# Altium schematic sheet list1.SchDoc(list1)
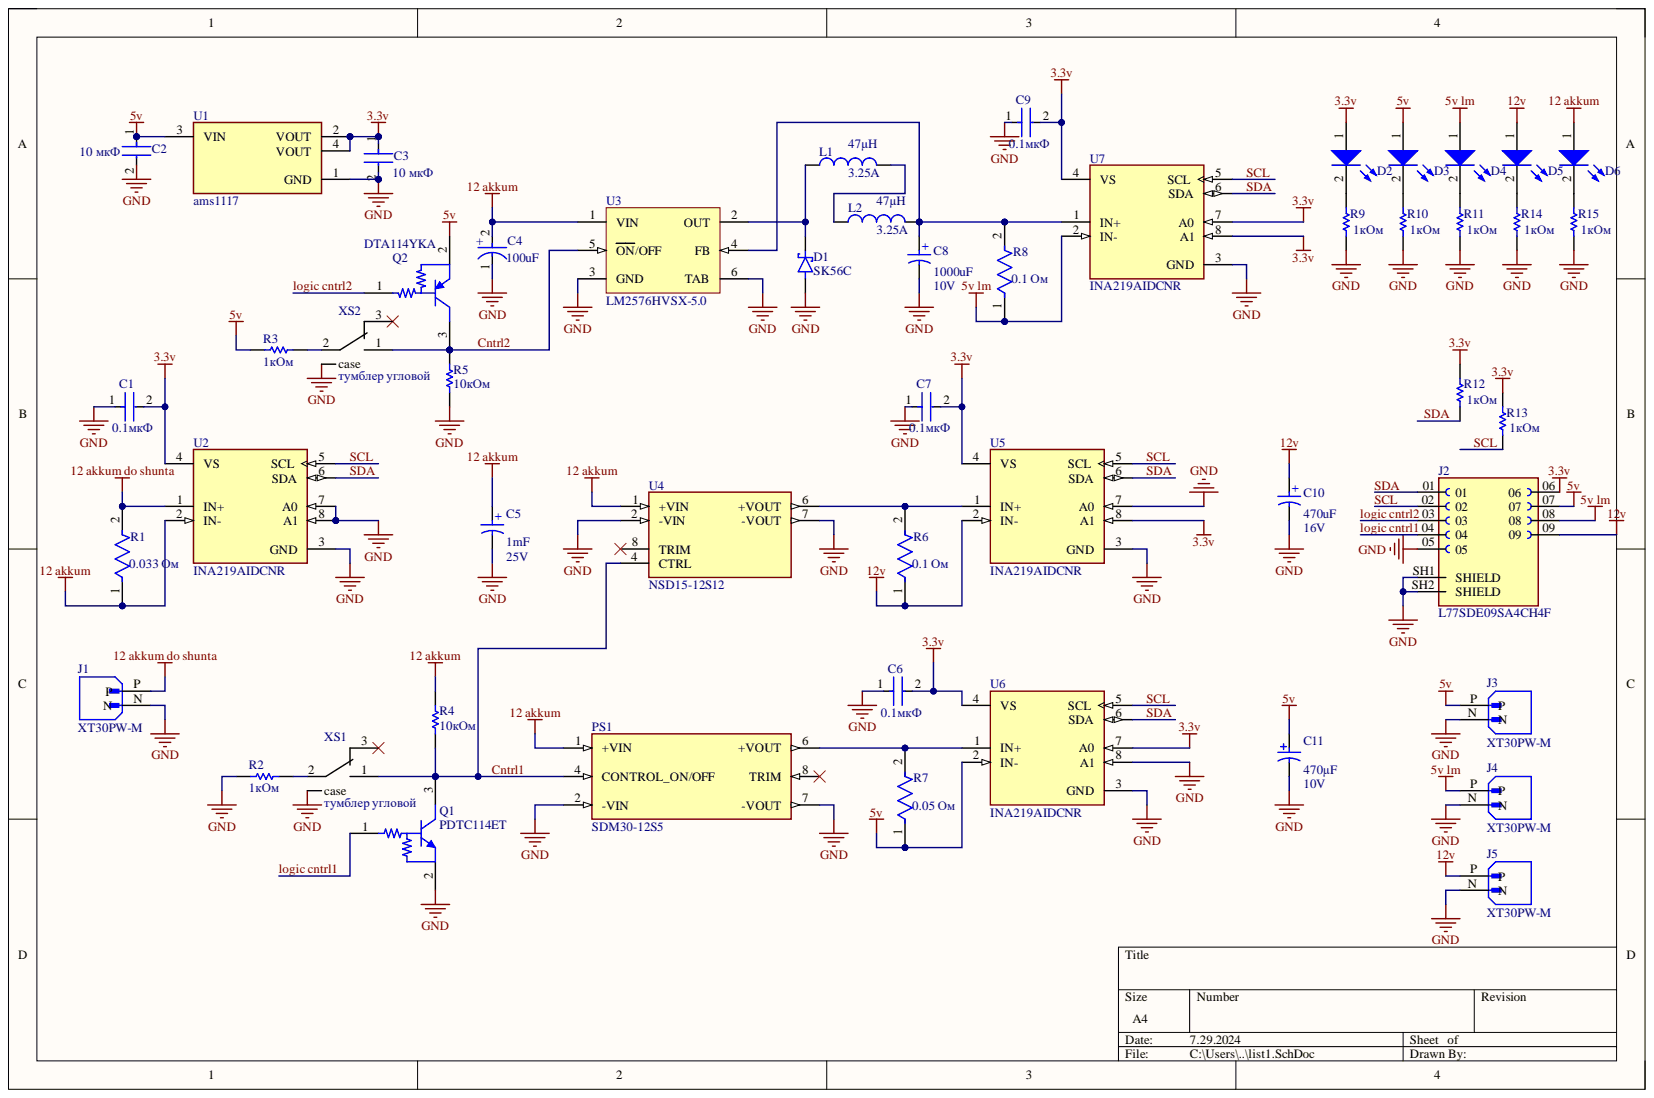
[diagram p0: list1.SchDoc]

## Parts
C1  value 0.1мкФ  [FP-C0805C-DN-IPC_A]  (hidden comment: C0805C104K5RACTU)
C2  value 10 мкФ  [FP-C0805C-DN-IPC_A]  (hidden comment: C0805C104K5RACTU)
C3  value 10 мкФ  [FP-C0805C-DN-IPC_A]  (hidden comment: C0805C104K5RACTU)
C4  Capacitance: 100uF  [FP-T495D107K016ATE125-MFG]  (hidden comment: T495D107K016ATE125)
C5  Capacitance: 1mF  Voltage Rating: 25V  [10*12.5]  (hidden comment: 865060257010)
C6  value 0.1мкФ  [FP-C0805C-DN-IPC_A]  (hidden comment: C0805C104K5RACTU)
C7  value 0.1мкФ  [FP-C0805C-DN-IPC_A]  (hidden comment: C0805C104K5RACTU)
C8  Capacitance: 1000uF  Voltage Rating: 10V  [WCAP-ASLL_D10H10.5]  (hidden comment: 865060257010)
C9  value 0.1мкФ  [FP-C0805C-DN-IPC_A]  (hidden comment: C0805C104K5RACTU)
C10  Capacitance: 470uF  Voltage Rating: 16V  [WCAP-ASLL_D10H10.5]  (hidden comment: 865060257010)
C11  Capacitance: 470µF  Rated Voltage: 10V  [PNSC-FK-V-F_V]  (hidden comment: EEEFK1C471P)
D1  SK56C  [DIOM6859X262N]
D2  Infrared, 1.5V, 30°  [WL-TIRC_3mm]  (value not drawn)
D3  Infrared, 1.5V, 30°  [WL-TIRC_3mm]  (value not drawn)
D4  Infrared, 1.5V, 30°  [WL-TIRC_3mm]  (value not drawn)
D5  Infrared, 1.5V, 30°  [WL-TIRC_3mm]  (value not drawn)
D6  Infrared, 1.5V, 30°  [WL-TIRC_3mm]  (value not drawn)
J1  XT30PW-M  [AMASS_XT30PW-M]
J2  L77SDE09SA4CH4F  [AMPHENOL_L77SDE09SA4CH4F]
J3  XT30PW-M  [AMASS_XT30PW-M]
J4  XT30PW-M  [AMASS_XT30PW-M]
J5  XT30PW-M  [AMASS_XT30PW-M]
L1  Current Rating: 3.25A  Inductance: 47µH  [SUMI-CDRH127_V]  (hidden comment: CDRH127/LDNP-470MC)
L2  Current Rating: 3.25A  Inductance: 47µH  [SUMI-CDRH127_V]  (hidden comment: CDRH127/LDNP-470MC)
PS1  SDM30-12S5  [CONV_SDM30-12S5]
Q1  PDTC114ET  [ONSC-SC-59-3-318D-04_V]
Q2  DTA114YKA  [ONSC-SOT-23-3-318-08_V]
R1  CRCW25121R00FKEG  [FP-CRCW2512-e3-IPC_A]  (value not drawn)
R2  Package Quantity: 1кОм  [RESC2013X70X40ML20T20]  (hidden comment: ERJ-6ENF1001V)
R3  Package Quantity: 1кОм  [RESC2013X70X40ML20T20]  (hidden comment: ERJ-6ENF1001V)
R4  Package Quantity: 10кОм  [RESC2013X70X40ML20T20]  (hidden comment: ERJ-6ENF1001V)
R5  Package Quantity: 10кОм  [RESC2013X70X40ML20T20]  (hidden comment: ERJ-6ENF1001V)
R6  CRCW25121R00FKEG  [FP-CRCW2512-e3-IPC_A]  (value not drawn)
R7  CRCW25121R00FKEG  [FP-CRCW2512-e3-IPC_A]  (value not drawn)
R8  CRCW25121R00FKEG  [FP-CRCW2512-e3-IPC_A]  (value not drawn)
R9  Package Quantity: 1кОм  [RESC2013X70X40ML20T20]  (hidden comment: ERJ-6ENF1001V)
R10  Package Quantity: 1кОм  [RESC2013X70X40ML20T20]  (hidden comment: ERJ-6ENF1001V)
R11  Package Quantity: 1кОм  [RESC2013X70X40ML20T20]  (hidden comment: ERJ-6ENF1001V)
R12  Package Quantity: 1кОм  [RESC2013X70X40ML20T20]  (hidden comment: ERJ-6ENF1001V)
R13  Package Quantity: 1кОм  [RESC2013X70X40ML20T20]  (hidden comment: ERJ-6ENF1001V)
R14  Package Quantity: 1кОм  [RESC2013X70X40ML20T20]  (hidden comment: ERJ-6ENF1001V)
R15  Package Quantity: 1кОм  [RESC2013X70X40ML20T20]  (hidden comment: ERJ-6ENF1001V)
U1  ams1117  [FP-ams1117-IPC_A]
U2  INA219AIDCNR  [FP-DCN0008A-IPC_C]
U3  LM2576HVSX-5.0  [KTT0005B_M]
U4  NSD15-12S12  [CONV_NSD15-12S5]
U5  INA219AIDCNR  [FP-DCN0008A-IPC_C]
U6  INA219AIDCNR  [FP-DCN0008A-IPC_C]
U7  INA219AIDCNR  [FP-DCN0008A-IPC_C]
XS1  тумблер угловой  [тумблер угловой]
XS2  тумблер угловой  [тумблер угловой]

## Nets
3.3v: C1.2 C3.1 C6.2 C7.2 C9.2 D2.1 J2.06 R12.2 R13.2 U1.2(VOUT) U1.4(VOUT) U2.4(VS) U5.4(VS) U5.8(A1) U6.4(VS) U6.7(A0) U7.4(VS) U7.7(A0) U7.8(A1)
5v: C2.1 C11.1 D3.1 J2.07 J3.P Q2.2 R3.2 R7.1 U1.3(VIN) U6.2(IN-)
5v lm: D4.1 J2.08 J4.P R8.1 U7.2(IN-)
12 akkum: C4.2 C5.1 D6.1 PS1.1(+VIN) R1.1 R4.1 U2.2(IN-) U3.1(VIN) U4.1(+VIN)
12 akkum do shunta: J1.P R1.2 U2.1(IN+)
12v: C10.1 D5.1 J2.09 J5.P R6.1 U5.2(IN-)
GND: C1.1 C2.2 C3.2 C4.1 C5.2 C6.1 C7.1 C8.2 C9.1 C10.2 C11.2 D1.A J1.N J2.05 J2.SH1(SHIELD) J2.SH2(SHIELD) J3.N J4.N J5.N PS1.2(-VIN) PS1.7(-VOUT) Q1.2 R2.2 R5.1 R9.1 R10.1 R11.1 R14.1 R15.1 U1.1(GND) U2.3(GND) U2.7(A0) U2.8(A1) U3.3(GND) U3.6(TAB) U4.2(-VIN) U4.7(-VOUT) U5.3(GND) U5.7(A0) U6.3(GND) U6.8(A1) U7.3(GND) XS1.0(case) XS2.0(case)
Cntrl1: PS1.4(CONTROL_ON/OFF) Q1.3 R4.2 U4.4(CTRL) XS1.1
Cntrl2: Q2.3 R5.2 U3.5(ON/OFF) XS2.1
logic cntrl1: J2.04 Q1.1
logic cntrl2: J2.03 Q2.1
SCL: J2.02 R13.1 U2.5(SCL) U5.5(SCL) U6.5(SCL) U7.5(SCL)
SDA: J2.01 R12.1 U2.6(SDA) U5.6(SDA) U6.6(SDA) U7.6(SDA)
NetC8_1: C8.1 L2.2 R8.2 U3.4(FB) U7.1(IN+)
NetD1_C: D1.C L1.1 U3.2(OUT)
NetD2_2: D2.2 R9.2
NetD3_2: D3.2 R10.2
NetD4_2: D4.2 R11.2
NetD5_2: D5.2 R14.2
NetD6_2: D6.2 R15.2
NetL1_2: L1.2 L2.1
NetPS1_6: PS1.6(+VOUT) R7.2 U6.1(IN+)
NetR2_1: R2.1 XS1.2
NetR3_1: R3.1 XS2.2
NetR6_2: R6.2 U4.6(+VOUT) U5.1(IN+)

Not connected: PS1 8(TRIM); U4 8(TRIM); XS1 3; XS2 3

## Library data of the parts
C1 C6 C7 C9 = CMP-2007-00279-2: CAP CER 0.1UF 50V X7R 0805; Manufacturer: KEMET; Capacitance: 0.10 μF; Material: Ceramic; Temperature Coefficient: 30ppm/°C; Tolerance: 0.1; Automotive: No; Category: Cap; Component Kind: Standard; ComponentLink2Description: Datasheet; ComponentLink2URL: https://content.kemet.com/datasheets/KEM_C1003_C0G_SMD.pdf; Device Class L1: Passive Components; Device Class L2: Capacitors; Device Class L3: Ceramic Capacitors; Digikey Description: CAP CER 0.1UF 50V X7R 0805; Height: 0.88mm; Ipc Land Pattern Name: CAPC200125X78; Lead Free: Yes; Library Name: Components - cap0805.IntLib; Manufacturer Part Number: C0805C104K5RACTU; Mouser Description: Cap Ceramic 0.1uF 50V X7R 10% SMD 2.0 x 1.2 mm 125 C Paper T/R; Octopart: https://octopart.com/c0805c104k5ractu-kemet-143926; Package: C0805C-DN; RoHS: TRUE; Temperature: -55°C to +125°C; Temperature Characteristic: X7R; Temperature Range High: +125°C; Temperature Range Low: -55°C; Voltage Rating: 50 V
C2 C3 = CMP-2007-00279-2: CAP CER 10UF 50V X7R 0805; Manufacturer: KEMET; Capacitance: 0.10 μF; Material: Ceramic; Temperature Coefficient: 30ppm/°C; Tolerance: 0.1; Automotive: No; Category: Cap; Component Kind: Standard; ComponentLink2Description: Datasheet; ComponentLink2URL: https://content.kemet.com/datasheets/KEM_C1003_C0G_SMD.pdf; Device Class L1: Passive Components; Device Class L2: Capacitors; Device Class L3: Ceramic Capacitors; Digikey Description: CAP CER 0.1UF 50V X7R 0805; Height: 0.88mm; Ipc Land Pattern Name: CAPC200125X78; Lead Free: Yes; Library Name: Components - cap0805.IntLib; Manufacturer Part Number: C0805C104K5RACTU; Mouser Description: Cap Ceramic 0.1uF 50V X7R 10% SMD 2.0 x 1.2 mm 125 C Paper T/R; Octopart: https://octopart.com/c0805c104k5ractu-kemet-143926; Package: C0805C-DN; RoHS: TRUE; Temperature: -55°C to +125°C; Temperature Characteristic: X7R; Temperature Range High: +125°C; Temperature Range Low: -55°C; Voltage Rating: 50 V
C4 = CMP-2000-07207-2: CAP TANT 100UF 10% 16V 2917; Dielectric Material: Tantalum; Dissipation Factor: 8%; ESR (Equivalent Series Resistance): 125mR; Life (Hours): 2000Hour; Polarity: Polar; Case Code (Imperial): 2917; Case Code (Metric): 7343; Case/Package: 2917; Component Kind: Standard; Construction: Flat; Depth: 4.3mm; Failure Rate: 0.001%; Features: General Purpose; Height: 2.8mm; Height - Seated (Max): 0.122inch; Length: 7.3mm; Library Name: Altium Content Vault; Max Operating Temperature: 125°C; Min Operating Temperature: -55°C; Mount: Surface Mount; Number of Pins: 2; Package Quantity: 1; Packaging: Tape and Reel; Radiation Hardening: No; REACH SVHC: No SVHC; RoHS Compliant: Yes; Series: T495; Termination: SMD/SMT; Tolerance: 10%; Voltage: 16V; Voltage Rating: 16V; Voltage Rating (DC): 16V; Weight: 0.023634oz; Width: 0.169inch
C5 = konder: 865060257010 WCAP-ASLL Aluminum Electrolytic Capacitors 1000uf 25V; NexarPartId: 54202466; ESR (Equivalent Series Resistance): 99.9268mΩ; Impedance: 90mΩ; Insulation Resistance: 100kΩ; Leakage Current: 100µA; Life (Hours): 5000hours; Polarity: Polar; Ripple Current: 690mA; Tolerance: 20%; Voltage Rating (DC): 10V; Case/Package: Radial; Component Kind: Standard; Diameter: 10mm; Height: 10.5mm; Height - Seated (Max): 10.4902mm; Length: 12.5mm; Library Name: Components - 06.07.24 - 21.SchLib; Max Operating Temperature: 105°C; Min Operating Temperature: -55°C; Mount: Surface Mount; REACH SVHC: Unknown; Ripple Current (AC): 690mA; RoHS: Compliant
C8 = CMP-03913-54202466-2: 865060257010 WCAP-ASLL Aluminum Electrolytic Capacitors 1000uf 10v; ESR (Equivalent Series Resistance): 99.9268mΩ; Impedance: 90mΩ; Insulation Resistance: 100kΩ; Leakage Current: 100µA; Life (Hours): 5000hours; Polarity: Polar; Ripple Current: 690mA; Tolerance: 20%; Case/Package: Radial; Component Kind: Standard; Diameter: 10mm; Height: 10.5mm; Height - Seated (Max): 10.4902mm; Length: 10.5mm; Library Name: Components - 06.07.24 - 21.SchLib; Max Operating Temperature: 105°C; Min Operating Temperature: -55°C; Mount: Surface Mount; NexarPartId: 54202466; REACH SVHC: Unknown; Ripple Current (AC): 690mA; RoHS: Compliant; Voltage Rating (DC): 10V
C10 = CMP-03913-54202466-2: 865060257010 WCAP-ASLL Aluminum Electrolytic Capacitors 470uf 16v; ESR (Equivalent Series Resistance): 99.9268mΩ; Impedance: 90mΩ; Insulation Resistance: 100kΩ; Leakage Current: 100µA; Life (Hours): 5000hours; Polarity: Polar; Ripple Current: 690mA; Tolerance: 20%; Voltage Rating (DC): 10V; Case/Package: Radial; Component Kind: Standard; Diameter: 10mm; Height: 10.5mm; Height - Seated (Max): 10.4902mm; Length: 10.5mm; Library Name: Components - 06.07.24 - 21.SchLib; Max Operating Temperature: 105°C; Min Operating Temperature: -55°C; Mount: Surface Mount; NexarPartId: 54202466; REACH SVHC: Unknown; Ripple Current (AC): 690mA; RoHS: Compliant
C11 = CMP-2000-06204-1: Aluminum Electrolytic Capacitor, 470 uF, +/- 20%, 10 V, 2-Pin SMD (Size Code F), -55 to 105 degC, RoHS, Tape and Reel; Manufacturer: Panasonic; Impedance: 0.16O; Lifetime@Temperature: 2000Hrs@105°C; Ripple Current: 600mA; Type: Can; Case Size/Dimension: Ø8x10.2mm; Component Kind: Standard; Datasheet URL: http://industrial.panasonic.com/cdbs/www-data/pdf/RDE0000/ABA0000C1181.pdf; Datasheet Version: 03/2016; Library Name: Altium Content Vault; Manufacturer URL: http://www.panasonic.com/; Mounting Technology: SurfaceMount; Operating Temp Range: -55to+105°C; Operating Temp-Max: 105°C; Package Description: 2-Pin Electrolytic Aluminum Capacitor, Body 8.3 x 8.3 mm; Package Reference: FK-V-F; Package URL: http://industrial.panasonic.com/cdbs/www-data/pdf/RDE0000/DME0000COL48.pdf; Series: FK
D1 = SK56C: 5A, 60V, Schottky Rectifier; MF: Taiwan Semiconductor; Availability: In Stock; Check_prices: https://www.snapeda.com/parts/SK56C/Taiwan+Semiconductor/view-part/?ref=eda; Component Kind: Standard; Library Name: SK56C.SchLib; MP: SK56C; Package: SMC-2 Taiwan Semiconductor; Price: None; Purchase-URL: https://pricing.snapeda.com/search/part/SK56C/?ref=eda; SnapEDA_Link: https://www.snapeda.com/parts/SK56C/Taiwan+Semiconductor/view-part/?ref=snap
D2-D6 = 15400385F3590: WL-TIRC THT Infrared Round Waterclear, 3mm, 60mW/sr, Infrared, 1.5V, 30°; Manufacturer: Wurth Elektronik; Centroid Wavelength: 845nm; Chip Technology: AlGaAs; Category: Infrared LEDs; Component Kind: Standard; ComponentLink1Description: Website Link; ComponentLink1URL: https://www.we-online.com/redexpert/article/15400385F3590?ad; ComponentLink2Description: Datasheet Link; ComponentLink2URL: https://www.we-online.com/redexpert/spec/15400385F3590?ad; Diameter: 3.85mm; Emitting Color: Infrared; Forward Voltage: 1.5V; Height: 5.3mm; Library Name: WL-TIRC.SchLib; Manufacturer Part Number: 15400385F3590; Match Code: WL-TIRC; Mount: Through Hole; Operating Temperature Max: 85°C; Operating Temperature Min: -40°C; Packaging: Bulk; Radiant Intensity: 60mW/sr; Simulation: THT_3mm_15400385F3590; Viewing Angle: 30°
J1 J3-J5 = XT30PW-M: Socket; DC supply; XT30; male; PIN: 2; on PCBs; THT; Colour: yellow; MF: AMASS; Check_prices: https://www.snapeda.com/parts/XT30PW-M/AMASS/view-part/?ref=eda; Component Kind: Standard; Library Name: XT30PW-M.IntLib; MP: XT30PW-M; Package: None; SnapEDA_Link: https://www.snapeda.com/parts/XT30PW-M/AMASS/view-part/?ref=snap
J2 = L77SDE09SA4CH4F: Connector d-sub rt angle pcb recept 4-40 threaded hole w/screwlock 9 socket cont | Amphenol Commercial (Amphenol CS) L77SDE09SA4CH4F; MF: Amphenol ICC (Commercial Products); Check_prices: https://www.snapeda.com/parts/L77SDE09SA4CH4F/Amphenol/view-part/?ref=eda; Component Kind: Standard; Library Name: L77SDE09SA4CH4F.IntLib; MP: L77SDE09SA4CH4F; Package: None; SnapEDA_Link: https://www.snapeda.com/parts/L77SDE09SA4CH4F/Amphenol/view-part/?ref=snap
L1 L2 = CMP-2000-06084-1: Power Inductor, 10 uH, +/- 20%, -40 to 100 degC, 2-Pin SMD, RoHS, Tape and Reel; Manufacturer: Sumida; Catalog Drawings: CDRH127SeriesFrontCDRH127SeriesSideCDRH127SeriesBottom; DC Resistance (DCR): 21.6mOhmMax; Frequency - Test: 1kHz; Online Catalog: CDRHSeries; Other Names: 308-1457-1; Product Training Modules: PowerInductorBasics; Shielding: Shielded; Category: Inductors,Coils,Chokes; Component Kind: Standard; Current - Saturation: -; Datasheet URL: http://products.sumida.com/products/pdf/CDRH127.pdf; Datasheet Version: 01/2014; Family: FixedInductors; Frequency - Self Resonant: -; Height - Seated (Max): 0.315"(8.00mm); Library Name: Altium Content Vault; Manufacturer URL: http://www.sumida.com/; Material - Core: -; Mounting Technology: SurfaceMount; Mounting Type: SurfaceMount; Operating Temperature: -40°C~100°C; Package / Case: Nonstandard; Package Description: 2-Pin Surface Mount Device, Body 12 x 12 mm; Package Reference: CDRH127; Packaging: CutTape(CT); Q @ Freq: -; Ratings: -; Series: CDRH127; Size / Dimension: 0.472"Lx0.472"W(12.00mmx12.00mm); Standard Package: 1; Tolerance: ±20%; Type: -
PS1 = SDM30-12S5: Isolated Module DC DC Converter 1 Output 5V 5A 9.2V - 18V Input; MF: MEAN WELL; Check_prices: https://www.snapeda.com/parts/SDM30-12S5/MEAN%20WELL%20USA%20Inc./view-part/9848/?ref=eda; Component Kind: Standard; Digi-Key_Part_Number: 1866-4448-ND; Library Name: SDM30-12S5.SchLib; MP: SDM30-12S5; Package: DIP-8 Mean Well; Purchase-URL: https://pricing.snapeda.com/search/part/SDM30-12S5/?ref=eda; SnapEDA_Link: https://www.snapeda.com/parts/SDM30-12S5/MEAN%20WELL%20USA%20Inc./view-part/9848/?ref=snap
Q1 = CMP-0630-00125-1: Digital Transistor (BRT), R1=47 kOhm, R2=47 kOhm, NPN Transistor, 3-Pin SC-59, Pb-Free, Tape and Reel пох какой цифровой транзюк; Manufacturer: On Semiconductor; PartNumber: MUN2213T1G; hFE Min: 80; IC Continuous (A): 0.1; R1/R2 Typ: 1; V(BR)CEO Min (V): 50; Component Kind: Standard; ComponentLink1Description: Manufacturer URL; ComponentLink1URL: http://www.onsemi.com/; ComponentLink2Description: Datasheet; ComponentLink2URL: http://www.onsemi.cn/pub_link/Collateral/DTC144E-D.PDF; ComponentLink3Description: Package Specification; ComponentLink3URL: http://www.onsemi.cn/pub_link/Collateral/318D-04.PDF; DatasheetVersion: Rev. 2; Library Name: Components - NPN Digital.IntLib; Mounting Technology: Surface Mount; PackageDescription: 3-Pin Small Outline Transistor, Body 2.9 x 1.5 mm, Pitch 0.95 mm; PackageReference: SC-59-3-318D-04; PackageVersion: Rev. H, 06/2012; Packing: Tape and Reel; Polarity: NPN; RoHS: TRUE
Q2 = CMP-0630-00280-1: Digital Transistor (BRT), R1=4.7 kOhm, R2=infinity kOhm, PNP Transistor, 3-Pin SOT-23, Pb-Free, Tape and Reel; Manufacturer: On Semiconductor; PartNumber: SMMUN2116LT3G; hFE Min: 160; IC Continuous (A): 0.1; V(BR)CEO Min (V): 50; Component Kind: Standard; ComponentLink1Description: Manufacturer URL; ComponentLink1URL: http://www.onsemi.com/; ComponentLink2Description: Datasheet; ComponentLink2URL: http://www.onsemi.cn/pub_link/Collateral/DTA143T-D.PDF; ComponentLink3Description: Package Specification; ComponentLink3URL: http://www.onsemi.cn/pub_link/Collateral/318-08.PDF; DatasheetVersion: Rev. 2; Library Name: Components - PNP2 Digital.IntLib; Mounting Technology: Surface Mount; PackageDescription: 3-Pin Small Outline Transistor, Body 2.92 x 1.3 mm, Pitch 0.95 mm; PackageReference: SOT-23-3-318-08; PackageVersion: Rev. AP, 11/2009; Packing: Tape and Reel; Polarity: PNP; RoHS: TRUE
R1 = CMP-02407-006956-1: RES Thick Film, 1Ω, 1%, 1W, 100ppm/°C, 2512; Resistance: 0.033 Ом; Voltage Rating: 500V; Case Code (Imperial): 2512; Case Code (Metric): 6432; Case/Package: 2512; Component Kind: Standard; Composition: Thick Film; Contact Plating: Tin; Depth: 3.15mm; Features: Automotive AEC-Q200; Height: 0.6mm; Lead Free: Lead Free; Length: 6.3mm; Library Name: Components - 2512 res.IntLib; Max Operating Temperature: 155°C; Max Power Dissipation: 1W; Military Standard: Not; Min Operating Temperature: -55°C; Mount: Surface Mount; Number of Pins: 2; Number of Terminations: 2; Package Quantity: 2000; Packaging: Tape and Reel; Power Rating: 1W; Radiation Hardening: No; REACH SVHC: No SVHC; Temperature Coefficient: 100ppm/°C; Termination: SMD/SMT; Tolerance: 1%; Voltage Rating (DC): 500V; Weight: 0.001429oz; Width: 0.124inch
R2-R5 R9-R15 = CMP-2001-04375-5: Chip Resistor, 1 KOhm, +/- 1%, 0.125 W, -55 to 155 degC, 0805 (2012 Metric), RoHS, Tape and Reel; Manufacturer: Panasonic; Voltage Rating: 150 V; Component Kind: Standard; ComponentLink1Description: Manufacturer URL; ComponentLink1URL: http://www.panasonic.com/; ComponentLink2Description: Datasheet; ComponentLink2URL: http://industrial.panasonic.com/cdbs/www-data/pdf/RDA0000/AOA0000C86.pdf; DatasheetVersion: 04/2015; Height: 0.6 mm; Length: 2 mm; Library Name: Components res 0805.IntLib; Max Operating Temperature: 155 degC; Min Operating Temperature: -55 degC; Mount: Surface Mount; Mounting Technology: Surface Mount; PackageDescription: 2-Pin Surface Mount Device, Body 2 x 1.25 mm; PackageReference: 0805; Packaging: Cut Tape; REACH SVHC: Yes; RoHSCompliant: true
R6 R8 = CMP-02407-006956-1: RES Thick Film, 1Ω, 1%, 1W, 100ppm/°C, 2512; Resistance: 0.1 Ом; Voltage Rating: 500V; Case Code (Imperial): 2512; Case Code (Metric): 6432; Case/Package: 2512; Component Kind: Standard; Composition: Thick Film; Contact Plating: Tin; Depth: 3.15mm; Features: Automotive AEC-Q200; Height: 0.6mm; Lead Free: Lead Free; Length: 6.3mm; Library Name: Components - 2512 res.IntLib; Max Operating Temperature: 155°C; Max Power Dissipation: 1W; Military Standard: Not; Min Operating Temperature: -55°C; Mount: Surface Mount; Number of Pins: 2; Number of Terminations: 2; Package Quantity: 2000; Packaging: Tape and Reel; Power Rating: 1W; Radiation Hardening: No; REACH SVHC: No SVHC; Temperature Coefficient: 100ppm/°C; Termination: SMD/SMT; Tolerance: 1%; Voltage Rating (DC): 500V; Weight: 0.001429oz; Width: 0.124inch
R7 = CMP-02407-006956-1: RES Thick Film, 1Ω, 1%, 1W, 100ppm/°C, 2512; Resistance: 0.05 Ом; Voltage Rating: 500V; Case Code (Imperial): 2512; Case Code (Metric): 6432; Case/Package: 2512; Component Kind: Standard; Composition: Thick Film; Contact Plating: Tin; Depth: 3.15mm; Features: Automotive AEC-Q200; Height: 0.6mm; Lead Free: Lead Free; Length: 6.3mm; Library Name: Components - 2512 res.IntLib; Max Operating Temperature: 155°C; Max Power Dissipation: 1W; Military Standard: Not; Min Operating Temperature: -55°C; Mount: Surface Mount; Number of Pins: 2; Number of Terminations: 2; Package Quantity: 2000; Packaging: Tape and Reel; Power Rating: 1W; Radiation Hardening: No; REACH SVHC: No SVHC; Temperature Coefficient: 100ppm/°C; Termination: SMD/SMT; Tolerance: 1%; Voltage Rating (DC): 500V; Weight: 0.001429oz; Width: 0.124inch
U1 = CMP-209535-000002-1: 1A LOW DROPOUT VOLTAGE REGULATOR 3.3V; Component Kind: Standard; Library Name: Components - ldo 3.3 ams1117.IntLib; RoHS Compliant: Yes
U2 U5-U7 = CMP-0704-00041-3: IC CURRENT MONITOR 1% SOT23-8; Bandwidth: 3.4MHz; Common Mode Rejection Ratio: 100dB; Input Offset Voltage (Vos): 100uV; Max Input Voltage: 26V; Max Supply Current: 5mA; Max Supply Voltage: 5.5V; Min Input Voltage: 0V; Min Supply Voltage: 3V; Nominal Supply Current: 700uA; Number of Amplifiers: 1; Operating Supply Current: 1mA; Output Current: 10mA; Output Type: Digital; Accuracy: 1%; Case/Package: SOT-23-8; Component Kind: Standard; Contact Plating: Gold; Features: I²C; Height: 1.3mm; Lead Free: Lead Free; Length: 3mm; Library Name: Components - ina219.SchLib; Max Operating Temperature: 125°C; Min Operating Temperature: -40°C; Number of Pins: 8; Output Current per Channel: 10mA; Package Quantity: 3000; Packaging: Tape and Reel; Quiescent Current: 700uA; Radiation Hardening: No; REACH SVHC: No SVHC; RoHS Compliant: Yes; Series: Zero-Drift; Supply Current: 700uA; Weight: 0.001411oz; Width: 1.75mm
U3 = CMP-0069-00141-3: SIMPLE SWITCHER® 3A Step-Down Voltage Regulator, 5-pin TO-263; Manufacturer: Texas Instruments; PackageTopMarking: NSUZXYTTE#*LM2576*HVS-5.0 P+; PowerWise: N; Code_JEDEC: MO-169-AB; Component Kind: Standard; ComponentLink1Description: Datasheet URL; ComponentLink1URL: http://www.ti.com/general/docs/lit/getliterature.tsp?genericPartNumber=LM2576HV&fileType=pdf; CompType: Switcher; Library Name: Altium Content Vault; PackageDescription: TO-263, Molded, .400 x .390 x .180in, 5 Lead, .067in Pitch, Surface Mount; PackageReference: KTT0005B; PinCount: 5; RoHS: N
U4 = NSD15-12S12: Isolated Module DC DC Converter 1 Output 5V 3A 9.4V - 36V Input; MF: MEAN WELL; MP: NSD15-12S5; Component Kind: Standard; Digi-Key_Part_Number: 1866-3633-ND; Library Name: SnapEDAsch.SchLib; Package: DIP-8 Mean Well; SnapEDA_Link: https://www.snapeda.com/parts/NSD15-12S5/MEAN%20WELL%20USA%20Inc./view-part/356097/?ref=snap
XS1 XS2 = тумблер угловой: SMTS-102-2C4; Component Kind: Standard; Library Name: bibla2.SchLib
